# Altium schematic sheet 102-002-00130-00,K12蜂鸣器模块V1.0原理图.SchDoc
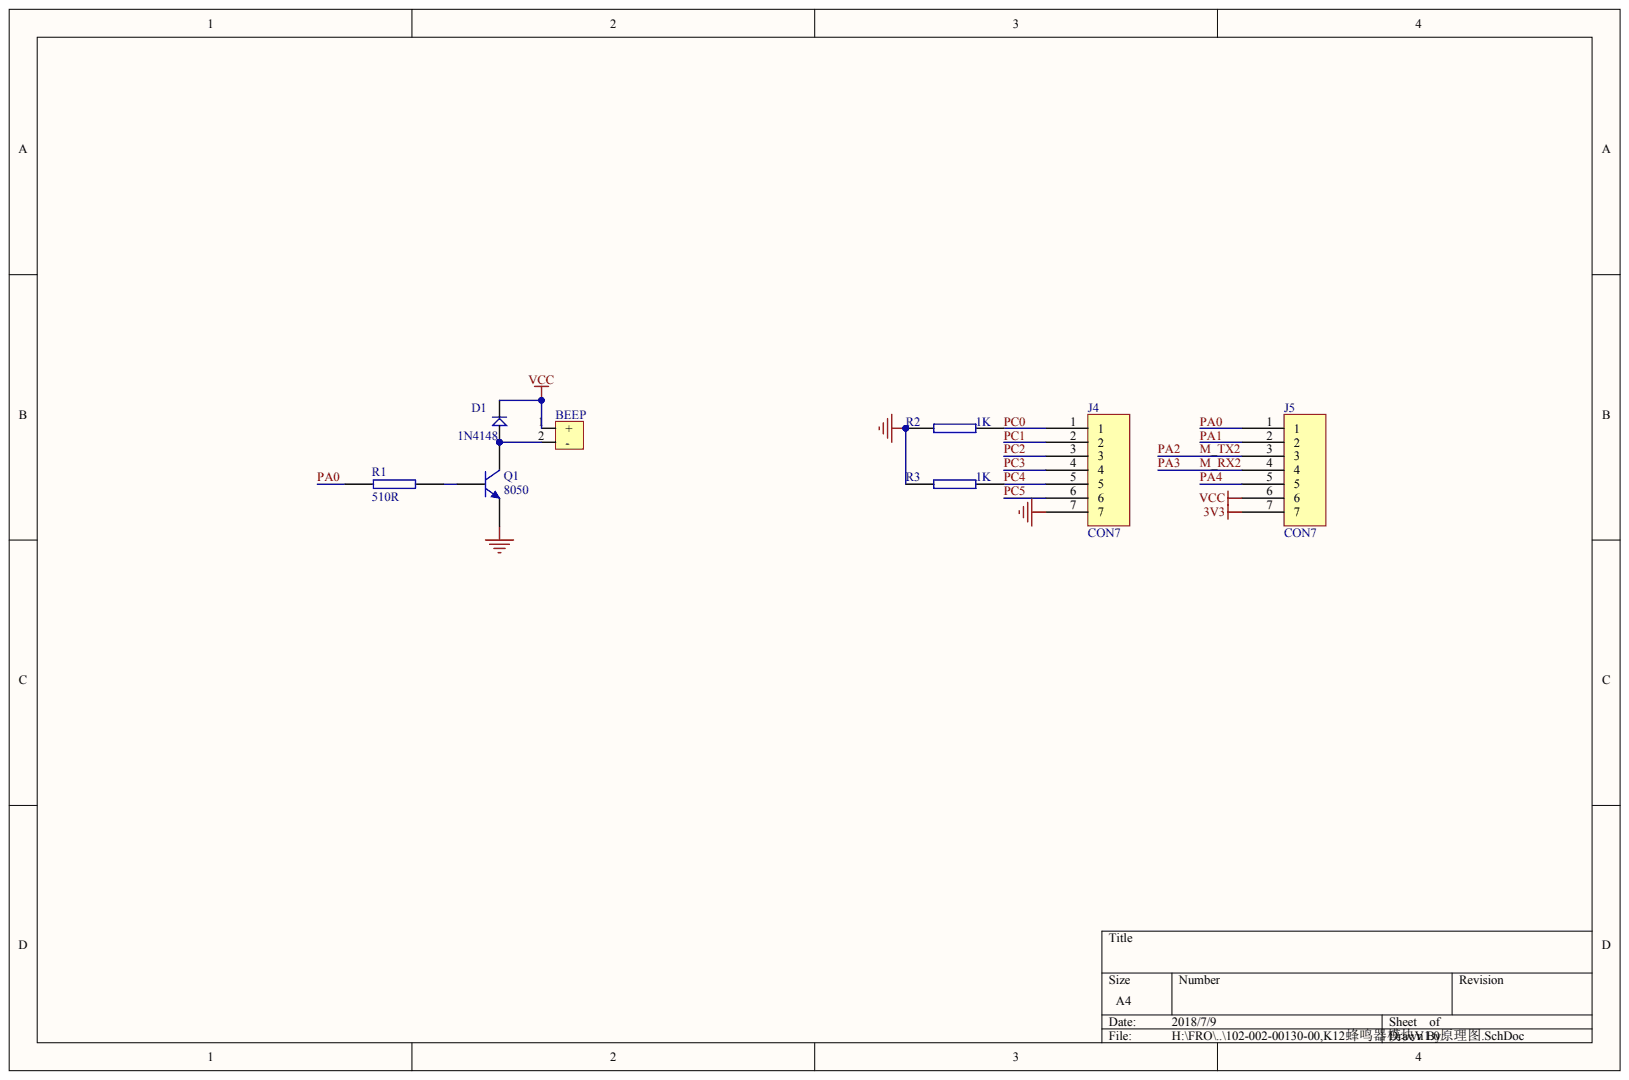
[diagram p0: 102-002-00130-00,K12蜂鸣器模块V1.0原理图.SchDoc]

## Parts
BEEP  BEER  (value not drawn)
D1  1N4148
J4  CON7
J5  CON7
Q1  8050
R1  510R
R2  1K
R3  1K

## Nets
3V3: J5.7
GND: J4.7 Q1.2 R2.1 R3.1
VCC: BEEP.1 D1.2 J5.6
M_RX2: J5.4
M_TX2: J5.3
PA0: J5.1 R1.2
PA1: J5.2
PA4: J5.5
PC0: J4.1 R2.2
PC1: J4.2
PC2: J4.3
PC3: J4.4
PC4: J4.5 R3.2
PC5: J4.6
NetBEEP_2: BEEP.2 D1.1 Q1.3
NetQ1_1: Q1.1 R1.1

## Library data of the parts
BEEP = (no symbol name): wuliaomingchen: 无源蜂鸣器
D1 = (no symbol name): wuliaobianma: 106-008-00003-00; wuliaoguige: LL4148/LL-34; wuliaomingchen: 稳压二极管
Q1 = (no symbol name): wuliaobianma: 107-001-00001-00; wuliaoguige: S8050/SOT-23; wuliaomingchen: 贴片三极管
R1 = (no symbol name): wuliaobianma: 103-001-00511-01; wuliaoguige: 0805/510Ω/F; wuliaomingchen: 贴片电阻
R2 R3 = (no symbol name): wuliaobianma: 103-001-00102-01; wuliaoguige: 0805/1KΩ/F; wuliaomingchen: 贴片电阻
